# Altium schematic sheet VFD_Encoder.SchDoc
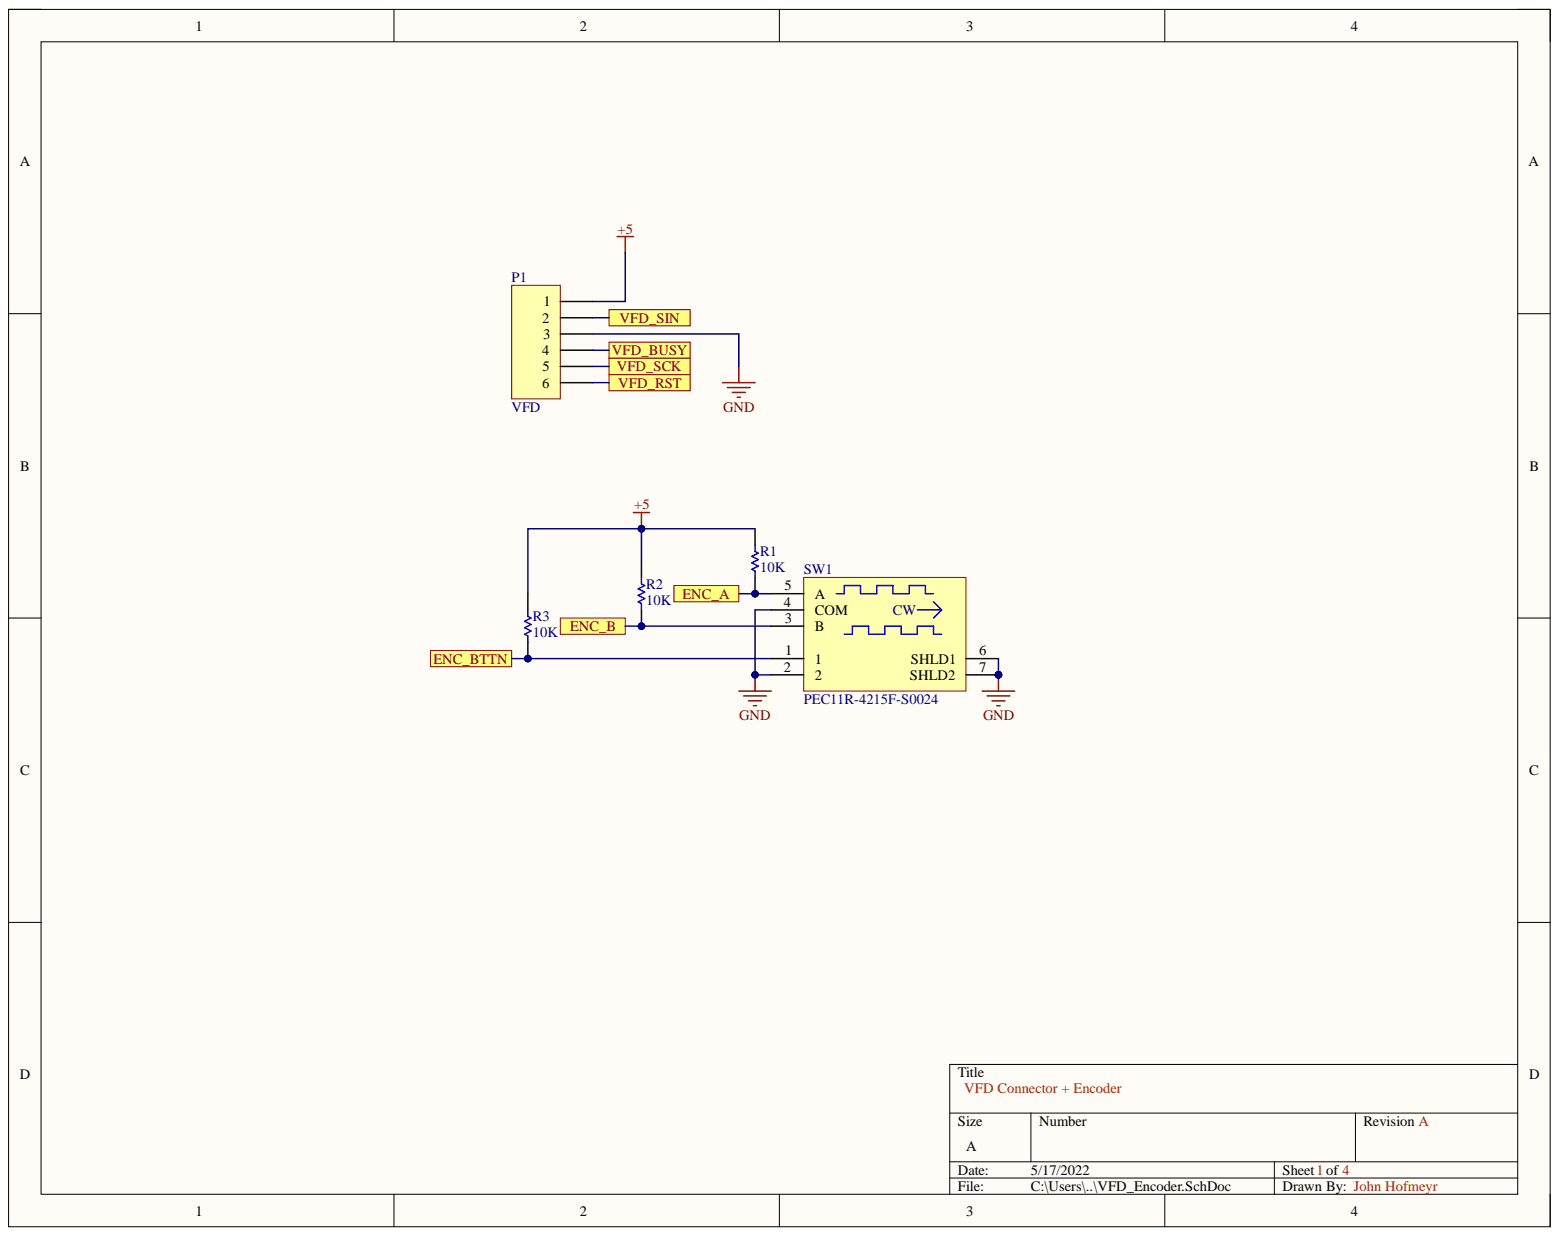
[diagram p0: VFD_Encoder.SchDoc]

## Parts
P1  VFD  [HDR1X6]
R1  10K  [RESC2013X50X40NL20T20]
R2  10K  [RESC2013X50X40NL20T20]
R3  10K  [RESC2013X50X40NL20T20]
SW1  PEC11R-4215F-S0024  [BOUR-PEC11R-4XXXF-SXXXX_V]

## Ports
ENC_A, ENC_B, ENC_BTTN, VFD_BUSY, VFD_RST, VFD_SCK, VFD_SIN

## Nets
+5: P1.1 R1.2 R2.2 R3.2
GND: P1.3 SW1.2 SW1.4(COM) SW1.6(SHLD1) SW1.7(SHLD2)
ENC_A: R1.1 SW1.5(A) ; port ENC_A
ENC_B: R2.1 SW1.3(B) ; port ENC_B
ENC_BTTN: R3.1 SW1.1 ; port ENC_BTTN
VFD_BUSY: P1.4 ; port VFD_BUSY
VFD_RST: P1.6 ; port VFD_RST
VFD_SCK: P1.5 ; port VFD_SCK
VFD_SIN: P1.2 ; port VFD_SIN

## Library data of the parts
P1 = Header 6: Header, 6-Pin; Component Kind: Standard; LatestRevisionDate: 17-Jul-2002; LatestRevisionNote: Re-released for DXP Platform.; Library Name: Miscellaneous Connectors.IntLib; Signal Integrity: Connector; Publisher: Altium Limited
R1-R3 = CMP-2001-03215-1: Manufacturer: Susumu; Part Number: RR1220P-103-D; Component Kind: Standard; Library Name: Altium Content Vault
SW1 = CMP-1747-00012-1: 12 mm Incremental Encoder, 24 Detent, -30 to 70 degC, 5-Pin THD, RoHS, Bulk; Case\Package: Axial; Power Rating: 250 mW; Resistance: 100 kohm; Tolerance: 1%; Voltage Rating: 250 V; Component Kind: Standard; ComponentLink1Description: Manufacturer URL; ComponentLink1URL: http://www.bourns.com/; ComponentLink2Description: Datasheet; ComponentLink2URL: http://www.bourns.com/docs/Product-Datasheets/PEC11R.pdf; DatasheetVersion: Jul-14; Length: 0.248 inch; Library Name: Altium Content Vault; Max Operating Temperature: 155 degC; Min Operating Temperature: -55 degC; Mount: Through Hole; Package Quantity: 200; PackageDescription: 5-Pin Through Hole Device, Body 12.5 x 13.4 mm; PackageReference: PEC11R-4XXXF-SXXXX; Packaging: Bulk; RoHSCompliant: true
